# Altium schematic sheet Secondary protection.SchDoc
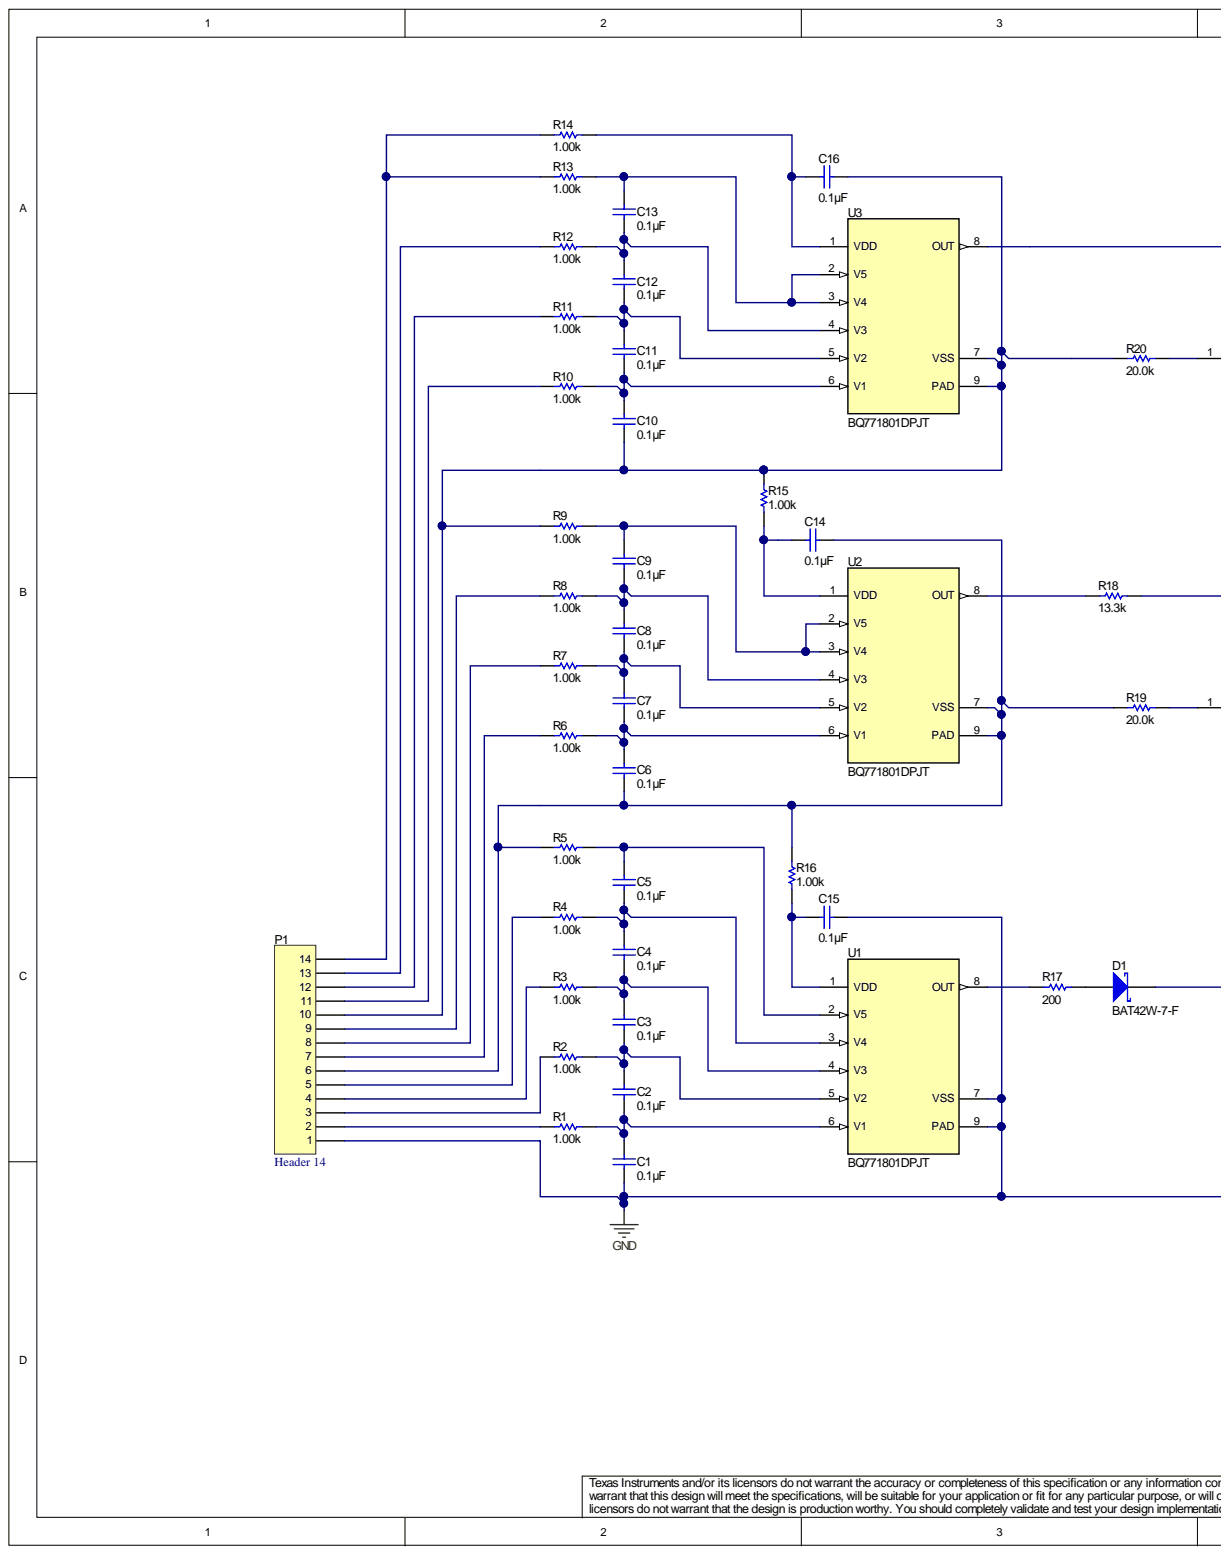
[diagram p0: Secondary protection.SchDoc, left]
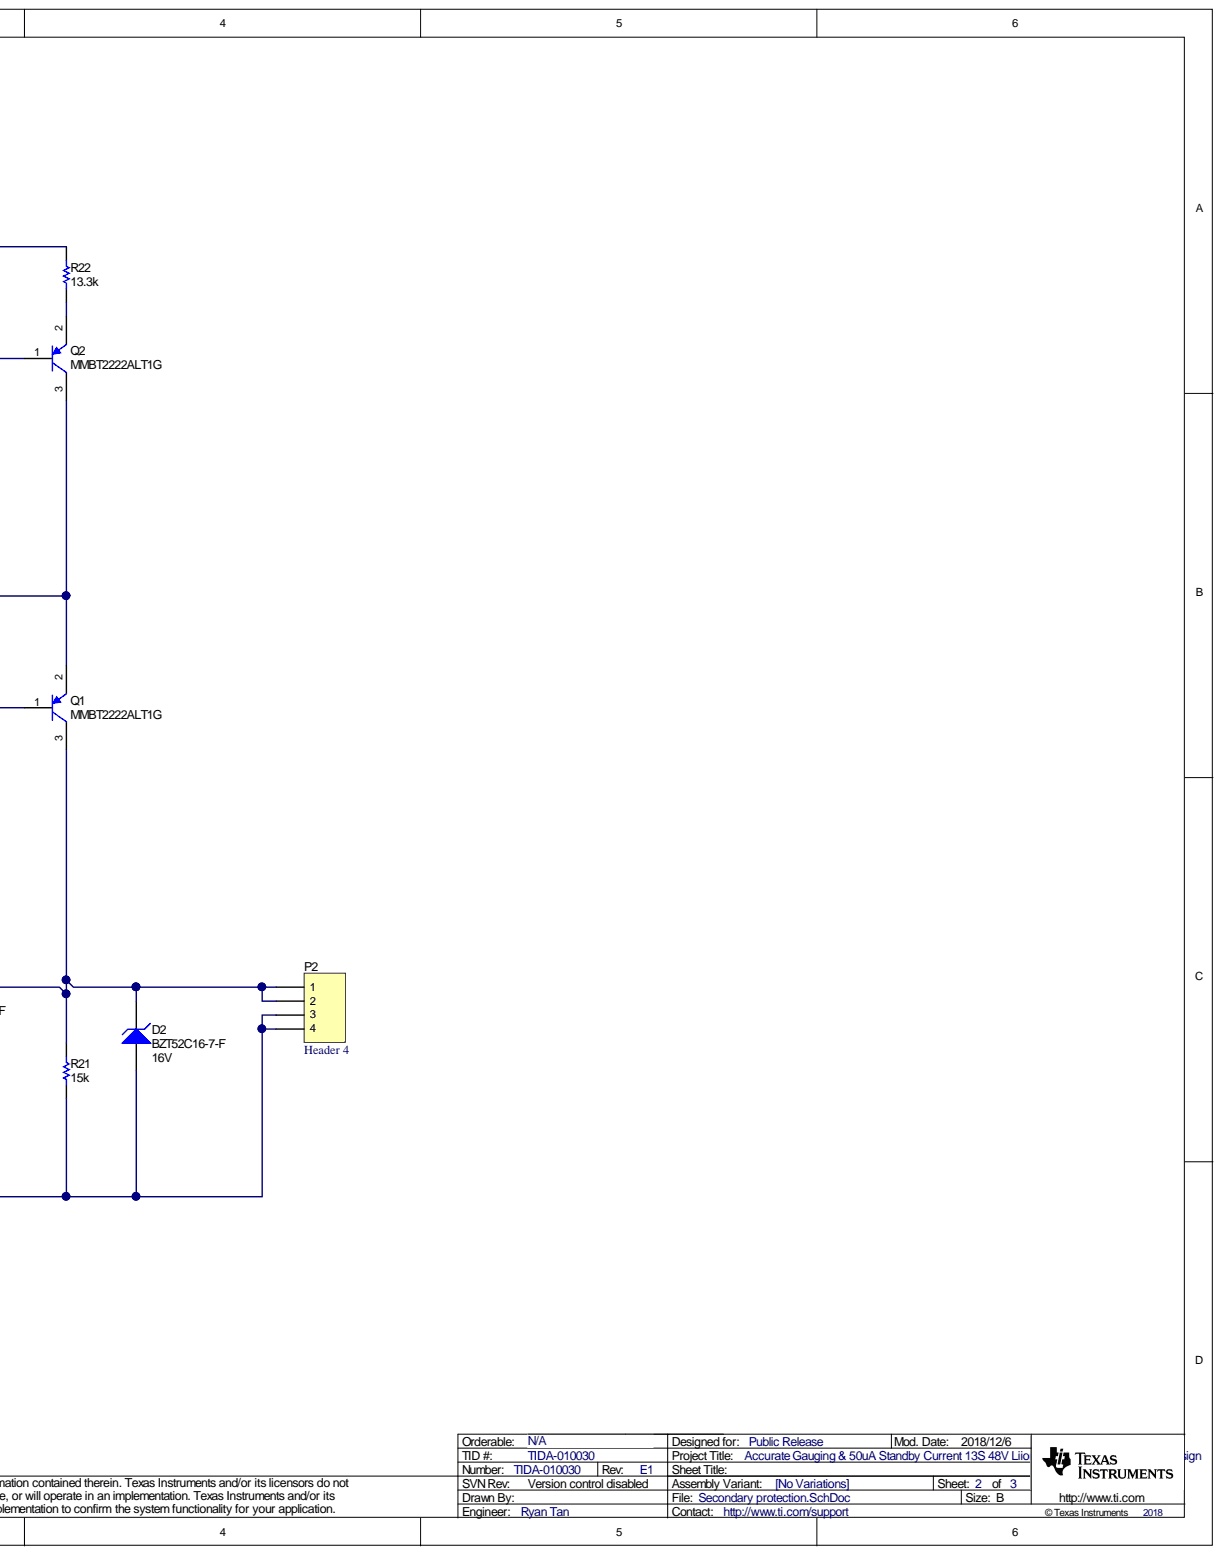
[diagram p1: Secondary protection.SchDoc, right]

## Parts
C1  ValueDisplayed: 0.1µF  [0603]  (hidden comment: GRM188R71H104KA93D)
C2  ValueDisplayed: 0.1µF  [0603]  (hidden comment: GRM188R71H104KA93D)
C3  ValueDisplayed: 0.1µF  [0603]  (hidden comment: GRM188R71H104KA93D)
C4  ValueDisplayed: 0.1µF  [0603]  (hidden comment: GRM188R71H104KA93D)
C5  ValueDisplayed: 0.1µF  [0603]  (hidden comment: GRM188R71H104KA93D)
C6  ValueDisplayed: 0.1µF  [0603]  (hidden comment: GRM188R71H104KA93D)
C7  ValueDisplayed: 0.1µF  [0603]  (hidden comment: GRM188R71H104KA93D)
C8  ValueDisplayed: 0.1µF  [0603]  (hidden comment: GRM188R71H104KA93D)
C9  ValueDisplayed: 0.1µF  [0603]  (hidden comment: GRM188R71H104KA93D)
C10  ValueDisplayed: 0.1µF  [0603]  (hidden comment: GRM188R71H104KA93D)
C11  ValueDisplayed: 0.1µF  [0603]  (hidden comment: GRM188R71H104KA93D)
C12  ValueDisplayed: 0.1µF  [0603]  (hidden comment: GRM188R71H104KA93D)
C13  ValueDisplayed: 0.1µF  [0603]  (hidden comment: GRM188R71H104KA93D)
C14  ValueDisplayed: 0.1µF  [0603]  (hidden comment: GRM188R71H104KA93D)
C15  ValueDisplayed: 0.1µF  [0603]  (hidden comment: GRM188R71H104KA93D)
C16  ValueDisplayed: 0.1µF  [0603]  (hidden comment: GRM188R71H104KA93D)
D1  BAT42W-7-F  [SOD-123]
D2  BZT52C16-7-F  value 16V  [SOD-123]
P1  Header 14  [HDR1X14]
P2  Header 4  [HDR1X4]
Q1  MMBT2222ALT1G  [SOT-23]
Q2  MMBT2222ALT1G  [SOT-23]
R1  value 1.00k  [0603]  (hidden comment: CRCW06031K00FKEA)
R2  value 1.00k  [0603]  (hidden comment: CRCW06031K00FKEA)
R3  value 1.00k  [0603]  (hidden comment: CRCW06031K00FKEA)
R4  value 1.00k  [0603]  (hidden comment: CRCW06031K00FKEA)
R5  value 1.00k  [0603]  (hidden comment: CRCW06031K00FKEA)
R6  value 1.00k  [0603]  (hidden comment: CRCW06031K00FKEA)
R7  value 1.00k  [0603]  (hidden comment: CRCW06031K00FKEA)
R8  value 1.00k  [0603]  (hidden comment: CRCW06031K00FKEA)
R9  value 1.00k  [0603]  (hidden comment: CRCW06031K00FKEA)
R10  value 1.00k  [0603]  (hidden comment: CRCW06031K00FKEA)
R11  value 1.00k  [0603]  (hidden comment: CRCW06031K00FKEA)
R12  value 1.00k  [0603]  (hidden comment: CRCW06031K00FKEA)
R13  value 1.00k  [0603]  (hidden comment: CRCW06031K00FKEA)
R14  value 1.00k  [0603]  (hidden comment: CRCW06031K00FKEA)
R15  value 1.00k  [0603]  (hidden comment: CRCW06031K00FKEA)
R16  value 1.00k  [0603]  (hidden comment: CRCW06031K00FKEA)
R17  value 200  [0603]  (hidden comment: CRCW0603200RJNEA)
R18  value 13.3k  [0603]  (hidden comment: CRCW060313K3FKEA)
R19  value 20.0k  [0603]  (hidden comment: CRCW060320K0FKEA)
R20  value 20.0k  [0603]  (hidden comment: CRCW060320K0FKEA)
R21  value 15k  [0603]  (hidden comment: CRCW060315K0JNEA)
R22  value 13.3k  [0603]  (hidden comment: CRCW060313K3FKEA)
U1  BQ771801DPJT  [DPJ0008A]
U2  BQ771801DPJT  [DPJ0008A]
U3  BQ771801DPJT  [DPJ0008A]

## Nets
GND: C1.2 C15.2 D2.2 P1.1 P2.3 P2.4 R21.2 U1.7(VSS) U1.9(PAD)
NetC1_1: C1.1 C2.2 R1.1 U1.6(V1)
NetC2_1: C2.1 C3.2 R2.1 U1.5(V2)
NetC3_1: C3.1 C4.2 R3.1 U1.4(V3)
NetC4_1: C4.1 C5.2 R4.1 U1.3(V4)
NetC5_1: C5.1 R5.1 U1.2(V5)
NetC6_1: C6.1 C7.2 R6.1 U2.6(V1)
NetC6_2: C6.2 C14.2 P1.6 R5.2 R16.1 R19.1 U2.7(VSS) U2.9(PAD)
NetC7_1: C7.1 C8.2 R7.1 U2.5(V2)
NetC8_1: C8.1 C9.2 R8.1 U2.4(V3)
NetC9_1: C9.1 R9.1 U2.2(V5) U2.3(V4)
NetC10_1: C10.1 C11.2 R10.1 U3.6(V1)
NetC10_2: C10.2 C16.2 P1.10 R9.2 R15.1 R20.1 U3.7(VSS) U3.9(PAD)
NetC11_1: C11.1 C12.2 R11.1 U3.5(V2)
NetC12_1: C12.1 C13.2 R12.1 U3.4(V3)
NetC13_1: C13.1 R13.1 U3.2(V5) U3.3(V4)
NetC14_1: C14.1 R15.2 U2.1(VDD)
NetC15_1: C15.1 R16.2 U1.1(VDD)
NetC16_1: C16.1 R14.1 U3.1(VDD)
NetD1_1: D1.1 D2.1 P2.1 P2.2 Q1.3 R21.1
NetD1_2: D1.2 R17.2
NetP1_2: P1.2 R1.2
NetP1_3: P1.3 R2.2
NetP1_4: P1.4 R3.2
NetP1_5: P1.5 R4.2
NetP1_7: P1.7 R6.2
NetP1_8: P1.8 R7.2
NetP1_9: P1.9 R8.2
NetP1_11: P1.11 R10.2
NetP1_12: P1.12 R11.2
NetP1_13: P1.13 R12.2
NetP1_14: P1.14 R13.2 R14.2
NetQ1_1: Q1.1 R19.2
NetQ1_2: Q1.2 Q2.3 R18.2
NetQ2_1: Q2.1 R20.2
NetQ2_2: Q2.2 R22.2
NetR17_1: R17.1 U1.8(OUT)
NetR18_1: R18.1 U2.8(OUT)
NetR22_1: R22.1 U3.8(OUT)

## Library data of the parts
C1-C16 = GRM188R71H104KA93D: CAP, CERM, 0.1 µF, 50 V,+/- 10%, X7R, 0603; Manufacturer: MuRata; AEC Category: Q200; Cap_F: 0.0000001; CompType: Capacitor; Green_Lifecycle: Active; Green_REACh: Passed; Polarized: N; SizeArea_mm2: 1.28; SizeAreaWB_mm2: 4.68; SizeX_mm: 1.6; SizeY_mm: 0.8; SizeZ_mm: 1; TaMax_C: 125; TaMin_C: -55; Tolerance_%: 10; U/M: EA; Value: 0.1uF; Value2: 50V; VDC_V: 50; AEC Qualified: ?; Cap_Case: 0603; Component Kind: Standard; ComponentLink1Description: Datasheet link; ComponentLink1URL: http://search.murata.co.jp/Ceramy/PnsearchViewAction.do?HnOFG=ON&HnNFG=ON&SEL_CNT=50&sLang=en&sKey=GRM188R71H104KA93D&x=9&y=9&search=startwith; Green_EU RoHS: Passed; Green_EU RoHS-2: Incomplete; LatestRevisionDate: 2017-06-22; Library Name: Capacitor_Ceramic.IntLib; MountType: SMT; PackageReference: 0603; PartNumber: GRM188R71H104KA93D; Published: 2008-06-19; RoHS: Y; Signal Integrity: Cap; Simulation: Cap; Supplier 1: Digi-Key; Supplier 2: Mouser; Supplier 3: Farnell; Supplier Part Number 1: 490-1519-1-ND; Supplier Part Number 2: 81-GRM39X104K50D; Supplier Part Number 3: 8820023; SymbolVersion: 2008-07-09; Technology: Ceramic; TempCo: X7R; Publisher: Texas Instruments
D1 = BAT42W-7-F: Diode, Schottky, 30 V, 0.2 A, SOD-123; Manufacturer: Diodes Inc.; AEC Category: Q101; Green_Lifecycle: Not Set; Green_REACh: Incomplete; Io_A: 0.2; SizeArea_mm2: 6.545; SizeAreaWB_mm2: 13.095; SizeX_mm: 3.85; SizeY_mm: 1.7; SizeZ_mm: 1.35; U/M: EA; Value: 30V; Value1: 0.2A; Value2: 1V; Vf_V: 1; Vr_V: 30; AEC Qualified: ?; Component Kind: Standard; ComponentLink1Description: Datasheet link; ComponentLink1URL: http://www.diodes.com/datasheets/ds30016.pdf; CompType: Diode; Green_EU RoHS: Incomplete; Green_EU RoHS-2: Incomplete; LatestRevisionDate: 2017-06-26; Library Name: Diode.IntLib; MountType: SMT; PackageReference: SOD-123; PartNumber: BAT42W-7-F; Published: 2008-06-26; RoHS: Y; Signal Integrity: Diode; Simulation: DI_BAT42W; Supplier 1: Digi-Key; Supplier 2: Mouser; Supplier 3: Farnell; Supplier Part Number 1: BAT42WDICT-ND; Supplier Part Number 2: 621-BAT42W-F; Supplier Part Number 3: 1843718; SymbolVersion: 2008-12-08; Type: Schottky; Publisher: Texas Instruments
D2 = BZT52C16-7-F: Diode, Zener, 16 V, 500 mW, AEC-Q101, SOD-123; Manufacturer: Diodes Inc.; AEC Category: Q101; AEC Qualified: Y; Power_W: 0.5; RJA_CperW: 338; SizeArea_mm2: 6.545; SizeAreaWB_mm2: 13.095; SizeX_mm: 3.85; SizeY_mm: 1.7; SizeZ_mm: 1.35; SymbolCreator: a0412235; U/M: EA; Value1: 0.5W; Vz_V: 16; Component Kind: Standard; ComponentLink1Description: Datasheet link; ComponentLink1URL: http://www.diodes.com/_files/datasheets/ds18004.pdf; CompType: Zener; LatestRevisionDate: 2017-06-20; LatestRevisionNote: Updated Supplier Data; Library Name: ZenerDiodes.IntLib; MountType: SMT; PackageReference: SOD-123; PartNumber: BZT52C16-7-F; Published: 2008-10-08; RoHS: Y; Signal Integrity: Diode; Supplier 1: Digi-Key; Supplier 2: Mouser; Supplier Part Number 1: BZT52C16-FDICT-ND; Supplier Part Number 2: 621-BZT52C16-F; SymbolVersion: 2010-08-25; Vr_V: N/A; Publisher: Texas Instruments
P1 = Header 14: Header, 14-Pin; Component Kind: Standard; LatestRevisionDate: 17-Jul-2002; LatestRevisionNote: Re-released for DXP Platform.; Library Name: Miscellaneous Connectors.IntLib; Signal Integrity: Connector; Publisher: Altium Limited
P2 = Header 4: Header, 4-Pin; Component Kind: Standard; LatestRevisionDate: 17-Jul-2002; LatestRevisionNote: Re-released for DXP Platform.; Library Name: Miscellaneous Connectors.IntLib; Signal Integrity: Connector; Publisher: Altium Limited
Q1 Q2 = MMBT3906-7-F, Diodes Inc.: Transistor, PNP, 40 V, 0.6 A, SOT-23; AEC Category: Q101; CompType: BJT; Green_Lifecycle: Active; Green_REACh: Passed; HfeMax: 400; IcRated_A: 0.2; NPN: 0; PNP: 1; SizeArea_mm2: 7.5; SizeAreaWB_mm2: 14; SizeX_mm: 2.5; SizeY_mm: 3; SizeZ_mm: 1.1; TaMax_C: 150; TaMin_C: -55; U/M: EA; Value: 40 V; Value1: 0.2 A; Vceo_V: 40; Vcesat1_V: 0.25; AEC Qualified: ?; Component Kind: Standard; ComponentLink1Description: Datasheet link; ComponentLink1URL: http://www.diodes.com/datasheets/ds30059.pdf; Green_EU RoHS: Passed; Green_EU RoHS-2: Passed; LatestRevisionDate: 2017-06-09; Library Name: Bipolar_Transistor.IntLib; Manufacturer: Diodes Inc.; MountType: SMT; PackageReference: SOT-23; PartNumber: MMBT2222ALT1G; Published: 2008-07-31; RoHS: Y; Simulation: DI_MMBT3906; Supplier 1: Digi-Key; Supplier 2: Newark; Supplier 3: Farnell; Supplier Part Number 1: MMBT3906-FDICT-ND; Supplier Part Number 2: 51R6815; Supplier Part Number 3: 1773629; SymbolVersion: 2008-07-31; Value2: 400; Publisher: Texas Instruments
R1-R16 = CRCW06031K00FKEA: RES, 1.00 k, 1%, 0.1 W, 0603; Manufacturer: Vishay-Dale; AEC Category: Q200; CompType: Resistor; EIA_Code: E96; Green_Lifecycle: Active; Green_REACh: Passed; Power_W: 0.1; Res_ohm: 1000; SizeArea_mm2: 1.28; SizeX_mm: 1.6; SizeY_mm: 0.8; SizeZ_mm: 1; TempCo: 100 ppm/C; U/M: EA; Value2: 0.1W; Value_Code: 1k00; VDC_V: 75; AEC Qualified: ?; Component Kind: Standard; ComponentLink1Description: Datasheet link; ComponentLink1URL: http://www.vishay.com/docs/20035/dcrcwe3.pdf; Green_EU RoHS: Passed with Exemption; Green_EU RoHS-2: Passed; LatestRevisionDate: 2017-06-13; Library Name: Resistor_0603.IntLib; MountType: SMT; PackageReference: 0603; PartNumber: CRCW06031K00FKEA; Published: 2008-06-26; RoHS: Y; Signal Integrity: Resistor; Simulation: Resistor; Supplier 1: Digi-Key; Supplier 2: Newark; Supplier 3: Mouser; Supplier Part Number 1: 541-1.00KHCT-ND; Supplier Part Number 2: 52K8015; Supplier Part Number 3: 71-CRCW0603-1.0K-E3; SymbolVersion: 2009-05-29; Technology: Thick Film; Tolerance_%: 1; Value1: 1%; ValueDisplayed: 1.00k; Publisher: Texas Instruments
R17 = CRCW0603200RJNEA: RES, 200, 5%, 0.1 W, 0603; Manufacturer: Vishay-Dale; AEC Category: Q200; CompType: Resistor; EIA_Code: E24; Green_Lifecycle: Active; Green_REACh: Passed; Power_W: 0.1; SizeArea_mm2: 1.28; SizeX_mm: 1.6; SizeY_mm: 0.8; SizeZ_mm: 1; TempCo: 200 ppm/C; Tolerance_%: 5; U/M: EA; Value2: 0.1W; Value_Code: 200R; VDC_V: 75; AEC Qualified: ?; Component Kind: Standard; ComponentLink1Description: Datasheet link; ComponentLink1URL: http://www.vishay.com/docs/20035/dcrcwe3.pdf; Green_EU RoHS: Passed with Exemption; Green_EU RoHS-2: Passed; LatestRevisionDate: 2017-06-13; Library Name: Resistor_0603.IntLib; MountType: SMT; PackageReference: 0603; PartNumber: CRCW0603200RJNEA; Published: 2008-06-26; Res_ohm: 200; RoHS: Y; Signal Integrity: Resistor; Simulation: Resistor; Supplier 1: Digi-Key; Supplier 2: Newark; Supplier 3: Mouser; Supplier Part Number 1: 541-200GCT-ND; Supplier Part Number 2: 59M6785; Supplier Part Number 3: 71-CRCW0603J-200-E3; SymbolVersion: 2009-05-29; Technology: Thick Film; Value1: 5%; ValueDisplayed: 200; Publisher: Texas Instruments
R18 R22 = CRCW060313K3FKEA: RES, 13.3 k, 1%, 0.1 W, 0603; Manufacturer: Vishay-Dale; AEC Category: Q200; CompType: Resistor; EIA_Code: E96; Green_Lifecycle: Active; Green_REACh: Passed; Power_W: 0.1; Res_ohm: 13300; SizeArea_mm2: 1.28; SizeX_mm: 1.6; SizeY_mm: 0.8; SizeZ_mm: 1; TempCo: 100 ppm/C; U/M: EA; Value2: 0.1W; Value_Code: 13k3; VDC_V: 75; AEC Qualified: ?; Component Kind: Standard; ComponentLink1Description: Datasheet link; ComponentLink1URL: http://www.vishay.com/docs/20035/dcrcwe3.pdf; Green_EU RoHS: Passed with Exemption; Green_EU RoHS-2: Passed; LatestRevisionDate: 2017-06-13; Library Name: Resistor_0603.IntLib; MountType: SMT; PackageReference: 0603; PartNumber: CRCW060313K3FKEA; Published: 2008-06-26; RoHS: Y; Signal Integrity: Resistor; Simulation: Resistor; Supplier 1: Digi-Key; Supplier 2: Newark; Supplier 3: Mouser; Supplier Part Number 1: 541-13.3KHCT-ND; Supplier Part Number 2: 52K8122; Supplier Part Number 3: 71-CRCW0603-13.3K-E3; SymbolVersion: 2009-05-29; Technology: Thick Film; Tolerance_%: 1; Value1: 1%; ValueDisplayed: 13.3k; Publisher: Texas Instruments
R19 R20 = CRCW060320K0FKEA: RES, 20.0 k, 1%, 0.1 W, 0603; Manufacturer: Vishay-Dale; AEC Category: Q200; CompType: Resistor; EIA_Code: E96; Green_Lifecycle: Active; Green_REACh: Passed; Power_W: 0.1; Res_ohm: 20000; SizeArea_mm2: 1.28; SizeX_mm: 1.6; SizeY_mm: 0.8; SizeZ_mm: 1; TempCo: 100 ppm/C; U/M: EA; Value2: 0.1W; Value_Code: 20k0; VDC_V: 75; AEC Qualified: ?; Component Kind: Standard; ComponentLink1Description: Datasheet link; ComponentLink1URL: http://www.vishay.com/docs/20035/dcrcwe3.pdf; Green_EU RoHS: Passed with Exemption; Green_EU RoHS-2: Passed; LatestRevisionDate: 2017-06-13; Library Name: Resistor_0603.IntLib; MountType: SMT; PackageReference: 0603; PartNumber: CRCW060320K0FKEA; Published: 2008-06-26; RoHS: Y; Signal Integrity: Resistor; Simulation: Resistor; Supplier 1: Digi-Key; Supplier 2: Newark; Supplier 3: Mouser; Supplier Part Number 1: 541-20.0KHCT-ND; Supplier Part Number 2: 52K8267; Supplier Part Number 3: 71-CRCW0603-20K-E3; SymbolVersion: 2009-05-29; Technology: Thick Film; Tolerance_%: 1; Value1: 1%; ValueDisplayed: 20.0k; Publisher: Texas Instruments
R21 = CRCW060315K0JNEA: RES, 15 k, 5%, 0.1 W, 0603; Manufacturer: Vishay-Dale; AEC Category: Q200; CompType: Resistor; EIA_Code: E24; Green_Lifecycle: Active; Green_REACh: Passed; Power_W: 0.1; Res_ohm: 15000; SizeArea_mm2: 1.28; SizeX_mm: 1.6; SizeY_mm: 0.8; SizeZ_mm: 1; TempCo: 200 ppm/C; Tolerance_%: 5; U/M: EA; Value2: 0.1W; Value_Code: 15k0; VDC_V: 75; AEC Qualified: ?; Component Kind: Standard; ComponentLink1Description: Datasheet link; ComponentLink1URL: http://www.vishay.com/docs/20035/dcrcwe3.pdf; Green_EU RoHS: Passed with Exemption; Green_EU RoHS-2: Passed; LatestRevisionDate: 2017-06-13; Library Name: Resistor_0603.IntLib; MountType: SMT; PackageReference: 0603; PartNumber: CRCW060315K0JNEA; Published: 2008-06-26; RoHS: Y; Signal Integrity: Resistor; Simulation: Resistor; Supplier 1: Digi-Key; Supplier 2: Newark; Supplier 3: Mouser; Supplier Part Number 1: 541-15KGCT-ND; Supplier Part Number 2: 59M6768; Supplier Part Number 3: 71-CRCW0603J-15K-E3; SymbolVersion: 2009-05-29; Technology: Thick Film; Value1: 5%; ValueDisplayed: 15k; Publisher: Texas Instruments
U1-U3 = CMP-0078897-2: Family 2-5S Overvoltage Protector with Internal Delay Timer, DPJ0008A (WSON-8); Alternate Manufacturer: Texas Instruments; Alternate PartNumber: BQ771801DPJR; AEC Category: Q200; AEC Qualified: N; CompType: IC; SizeArea_mm2: 12; SizeAreaWB_mm2: 20; SizeX_mm: 4; SizeY_mm: 3; SizeZ_mm: 0.8; Component Kind: Standard; ComponentLink1Description: Datasheet Link; ComponentLink1URL: http://www.ti.com/lit/ds/symlink/bq7718.pdf; ComponentLink2Description: Product Folder; ComponentLink2URL: http://www.ti.com/product/bq7718; Library Name: Altium Vault - TI; Manufacturer: Texas Instruments; MountType: SMT; PackageDescription: WSON-8; PackageReference: DPJ0008A; PartNumber: BQ771801DPJT; RoHS: Y
